# Altium schematic sheet POWER.SchDoc
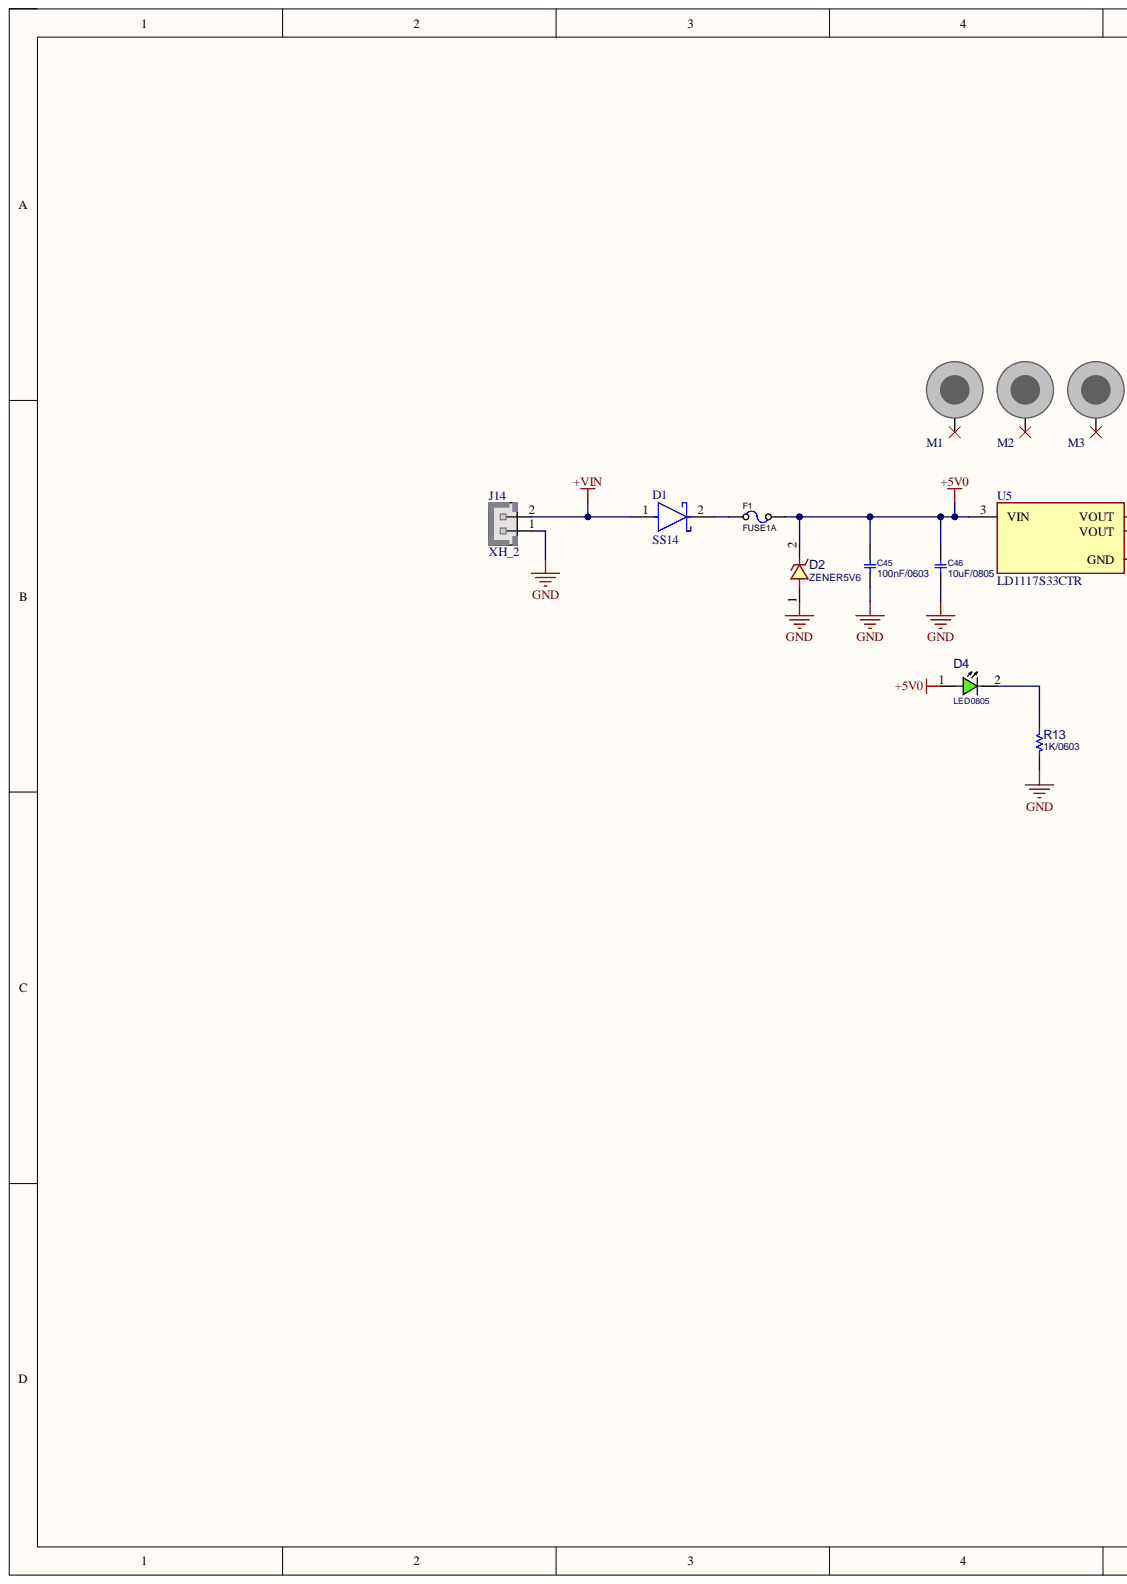
[diagram p0: POWER.SchDoc, left]
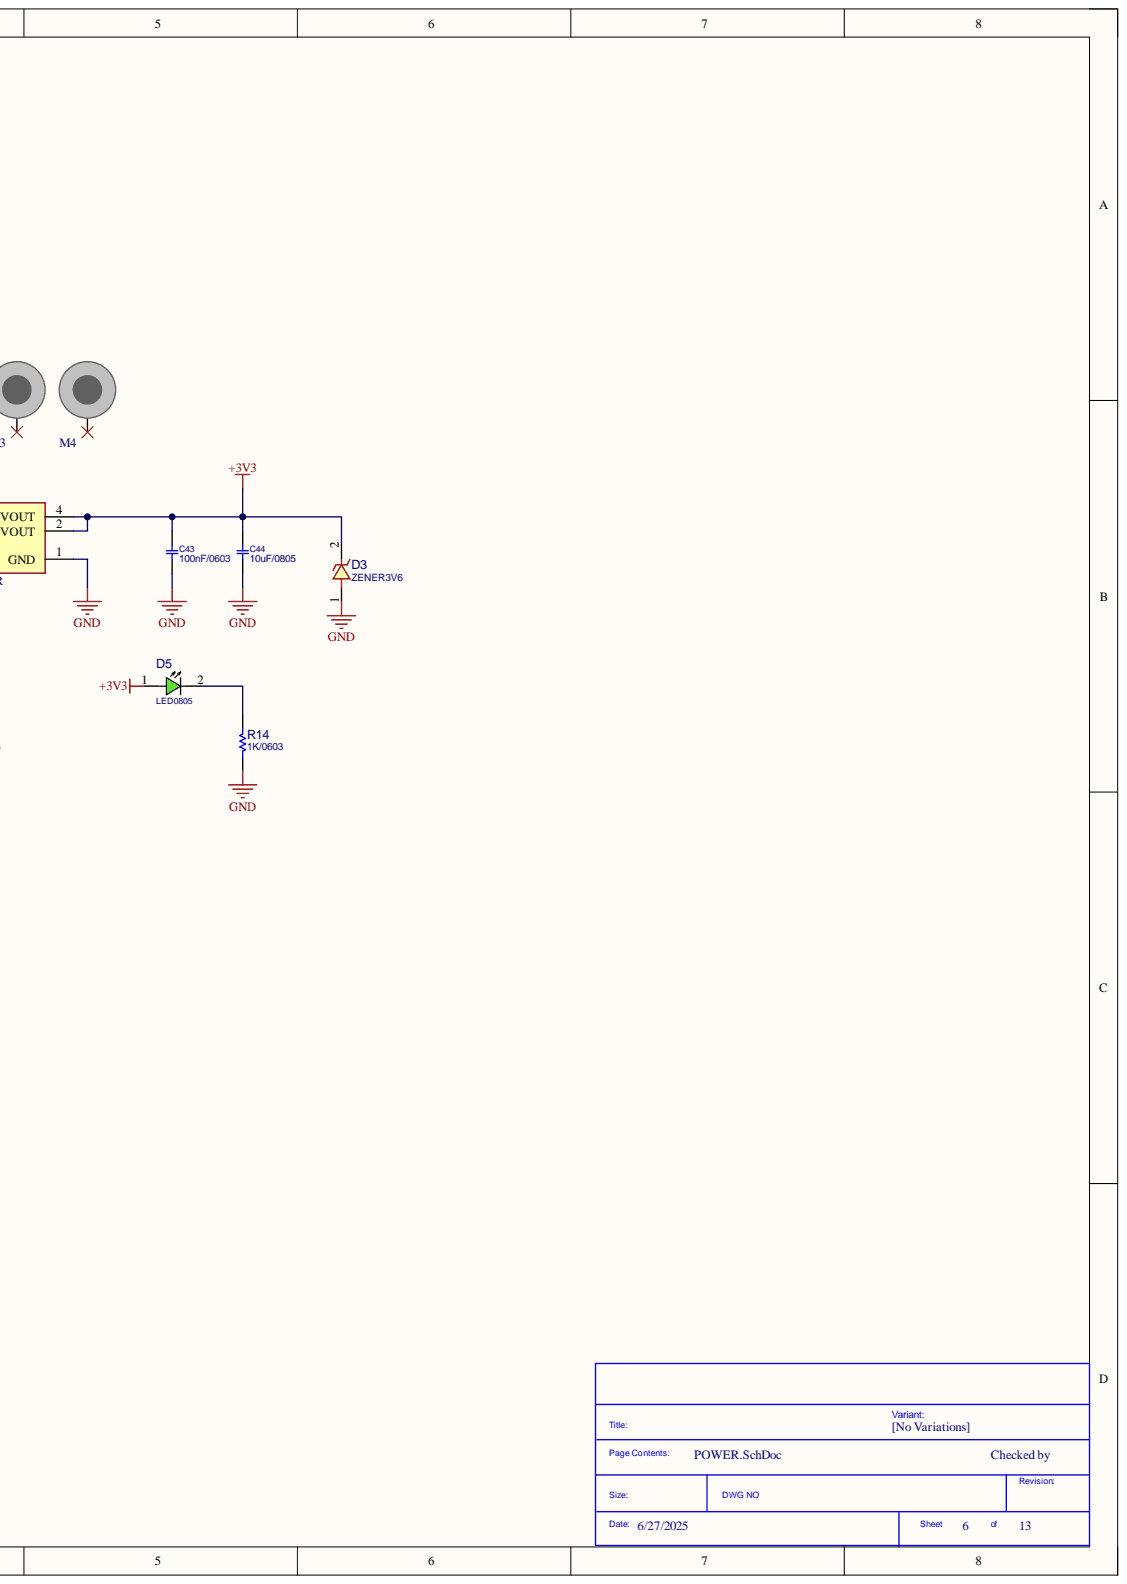
[diagram p1: POWER.SchDoc, right]

## Parts
C43  100nF/0603  [C0603]
C44  10uF/0805  [C0805]
C45  100nF/0603  [C0603]
C46  10uF/0805  [C0805]
D1  SS14  [FP-403AE-MFG]
D2  ZENER5V6  [D_SOD123W]
D3  ZENER3V6  [D_SOD123W]
D4  LED0805  [LED0805]
D5  LED0805  [LED0805]
F1  FUSE1A  [F1206]
J14  XH_2  [XH_2]
M1  DNP/MH  [SCMH_M3FT]  (value not drawn)  (no BOM)
M2  DNP/MH  [SCMH_M3FT]  (value not drawn)  (no BOM)
M3  DNP/MH  [SCMH_M3FT]  (value not drawn)  (no BOM)
M4  DNP/MH  [SCMH_M3FT]  (value not drawn)  (no BOM)
R13  1K/0603  [R0603]
R14  1K/0603  [R0603]
U5  LD1117S33CTR  [FP-SOT-223-0046067_14-MFG]

## Nets
+3V3: C43.2 C44.2 D3.2 D5.1 U5.2(VOUT) U5.4(VOUT)
+5V0: C45.2 C46.2 D2.2 D4.1 F1.2 U5.3(VIN)
+VIN: D1.1 J14.2
GND: C43.1 C44.1 C45.1 C46.1 D2.1 D3.1 J14.1 R13.1 R14.1 U5.1(GND)
NetD1_2: D1.2 F1.1
NetD4_2: D4.2 R13.2
NetD5_2: D5.2 R14.2

Not connected: M1 1; M2 1; M3 1; M4 1

## Library data of the parts
C43 C45 = CAP_SMD_MLCC_0603: CAP, MLCC; MANF_P#: MANF_PART#; PARAM: -, -%; PARAM2: -V; ASSEMBLY: ASSEMBLY; Component Kind: Standard; Library Name: passive_smd.SchLib; MANF: -; PRICE: 100= 1$; VALUE: 100nF/0603
C44 C46 = CAP_SMD_MLCC: CAP, MLCC; DESC: CAP, MLCC, 1nF, 50V, C0G, 10%, 0402; MANF: Murata; MANF_P#: MANF_PART#; PARAM: C0G, 10%; PARAM2: 50V; ASSEMBLY: ASSEMBLY; Component Kind: Standard; Library Name: passive_smd.SchLib; PRICE: 100= 1$; VALUE: 10uF/0805
D1 = CMP-2000-04943-2: DIODE SCHOTTKY 40V 1A SMA; Manufacturer: ON Semiconductor; Max Junction Temp: +125°C; Max Surge Forward Current: 40A; Peak Reverse Current: 0.2mA; Standoff Height: 0.05mm; Automotive: No; Category: Diode; Component Kind: Standard; ComponentLink2Description: Datasheet; ComponentLink2URL: https://www.onsemi.com/pub/Collateral/SS19-D.PDF; Device Class L1: Discrete Semiconductors; Device Class L2: Diodes; Device Class L3: Schottky Diodes; Digikey Description: DIODE SCHOTTKY 40V 1A SMA; Forward Current: 1A; Height: 2.5mm; Lead Free: Yes; Library Name: Altium Content Vault; Manufacturer Part Number: SS14; Max Repetitive Reverse Voltage: 40V; Mouser Description: Schottky Diodes & Rectifiers SMA 40V 1.0A; Octopart: https://octopart.com/ss14-on+semiconductor-29205033; Package: 403AE; RoHS: TRUE; Temperature: -65°C to +125°C; Temperature Range High: +125°C; Temperature Range Low: -65°C
D2 D3 = DIODE_SMD_ZENER_DFLZ5V6: DIODE, ZENER, 1W, 5.1V; DESC: DESCRIPTION; MANF: Diodes; MANF_P#: DFLZ5V6-7; PARAM: 5.6V; PARAM2: 1W, 4Ohm, -55~150oC; ASSEMBLY: ASSEMBLY; Component Kind: Standard; Library Name: diode.SchLib; PRICE: 0.5$
  D2: VALUE: ZENER5V6
  D3: VALUE: ZENER3V6
D4 D5 = LED_SMD_0805: LED, SMD, 0805; DESC: DESCRIPTION; MANF_P#: MANF_PART#; PARAM2: 2.2V; ASSEMBLY: ASSEMBLY; Component Kind: Standard; Library Name: led(diode).SchLib; MANF: MANF; PRICE: PRICE; VALUE: LED0805
F1 = FUSE_160mA_1206: Fuse, 160mA, 63VDC, 1206, SMD, Fast Acting; MANF: Holly Fuse; MANF_P#: 12T-0160L; PARAM: 160mA; 63VDC; slow-blow; Component Kind: Standard; PARAM2: PARAM2; VALUE: FUSE1A; Library Name: protect_tvs_esd_fuse.SchLib
J14 = XH_2: PW10-x-M, JST-BxB-XH-A pitch: 2.5mm, 3A/250v; MANF: JST; MANF_P#: B2B-XH-A; PARAM: 3.0A; PARAM2: 250v; Component Kind: Standard; Library Name: conn_wire2board.SchLib; VALUE: XH_2
M1-M4 = SCMH_M3FT: SCREW mouting, M3, 3.0mm diameter and 6.0mm, FlatHead; ASSEMBLY: DNP; Library Name: mech_mountingHole.SchLib; VALUE: DNP/MH
R13 R14 = RES_SMD_0603: RESISTOR, SMD; DESC: DESCRIPTION; DIST#: MOUSER; PARAM: 1%, 0.1W; ASSEMBLY: ASSEMBLY; Component Kind: Standard; Library Name: passive_smd.SchLib; MANF: -; MANF_P#: -; PARAM2: -; PRICE: -; VALUE: 1K/0603
U5 = CMP-0244-00307-2: IC REG LINEAR 3.3V 800MA SOT223; Accuracy: 1%; Dropout Voltage: 1.1V; Input Bias Current: 5mA; Max Input Voltage: 15V; Max Output Current: 1.3A; Max Power Dissipation: 12W; Min Input Voltage: 5.3V; Number of Outputs: 1; Output Current: 950mA; Output Type: Fixed; Polarity: Positive; Quiescent Current: 10mA; Case/Package: SOT-223; Component Kind: Standard; Contact Plating: Tin; Height: 1.8mm; Lead Free: Lead Free; Length: 6.5mm; Library Name: Altium Content Vault; Max Operating Temperature: 125°C; Max Output Voltage: 3.3V; Max Supply Voltage: 15V; Min Operating Temperature: -40°C; Min Output Voltage: 3.3V; Min Supply Voltage: 3.3V; Mount: Surface Mount; Nominal Output Voltage: 3.3V; Number of Pins: 4; Number of Regulators: 1; Number of Terminals: 4; Output Voltage: 3.3V; Output Voltage Accuracy: 1%; Package Quantity: 1; Packaging: Tape and Reel; Power Dissipation: 12W; Radiation Hardening: No; REACH SVHC: No SVHC; Termination: SMD/SMT; Weight: 0.01lb; Width: 3.5mm
